# Altium schematic sheet MCU1.SchDoc
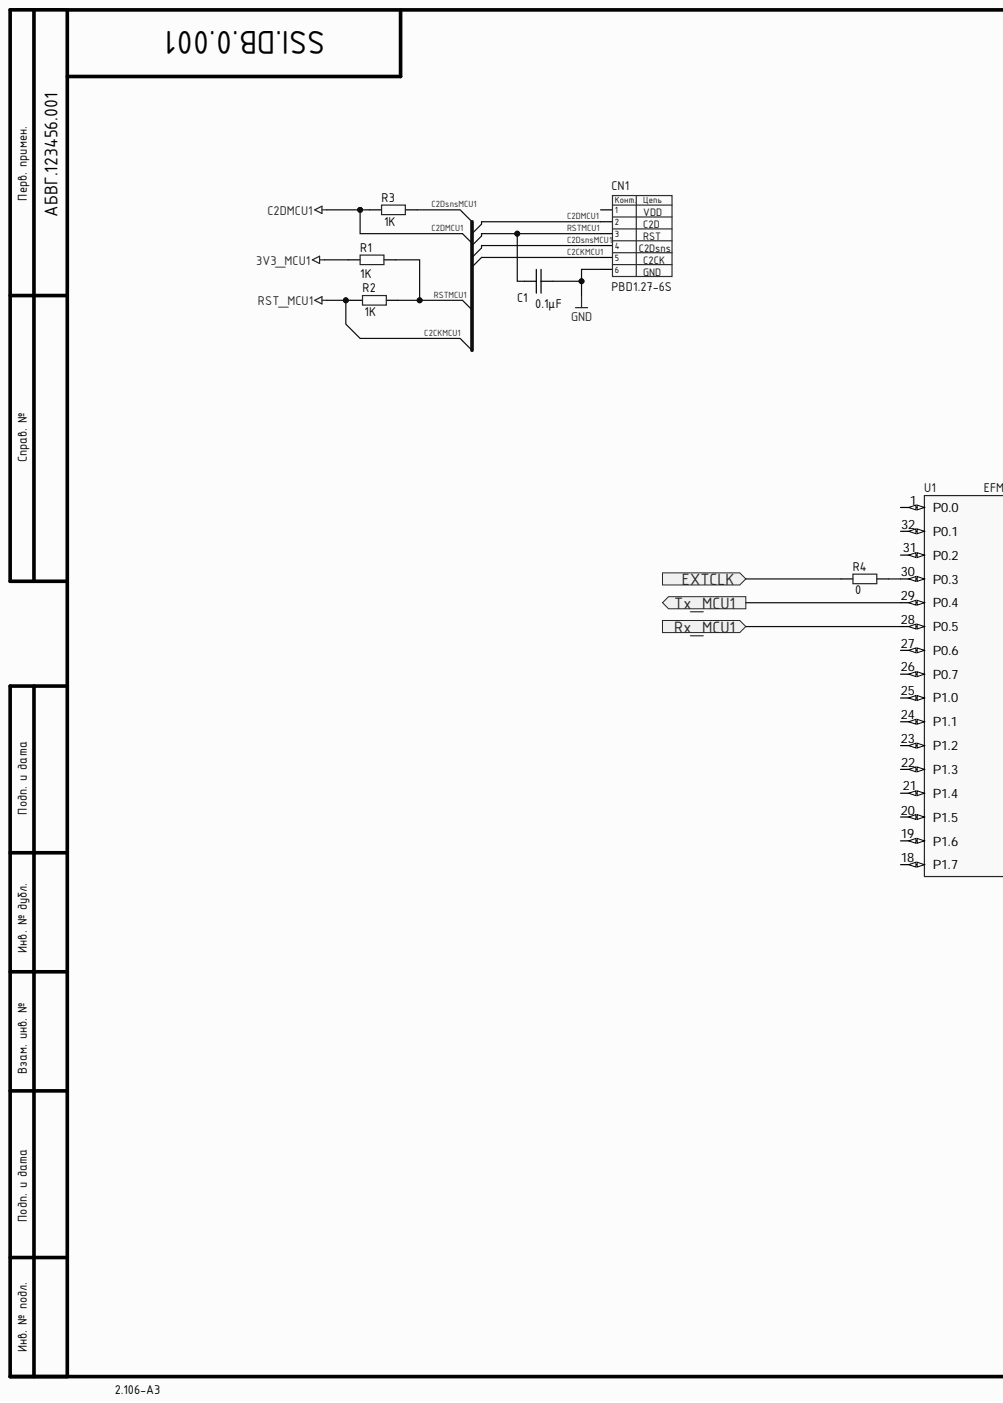
[diagram p0: MCU1.SchDoc, left]
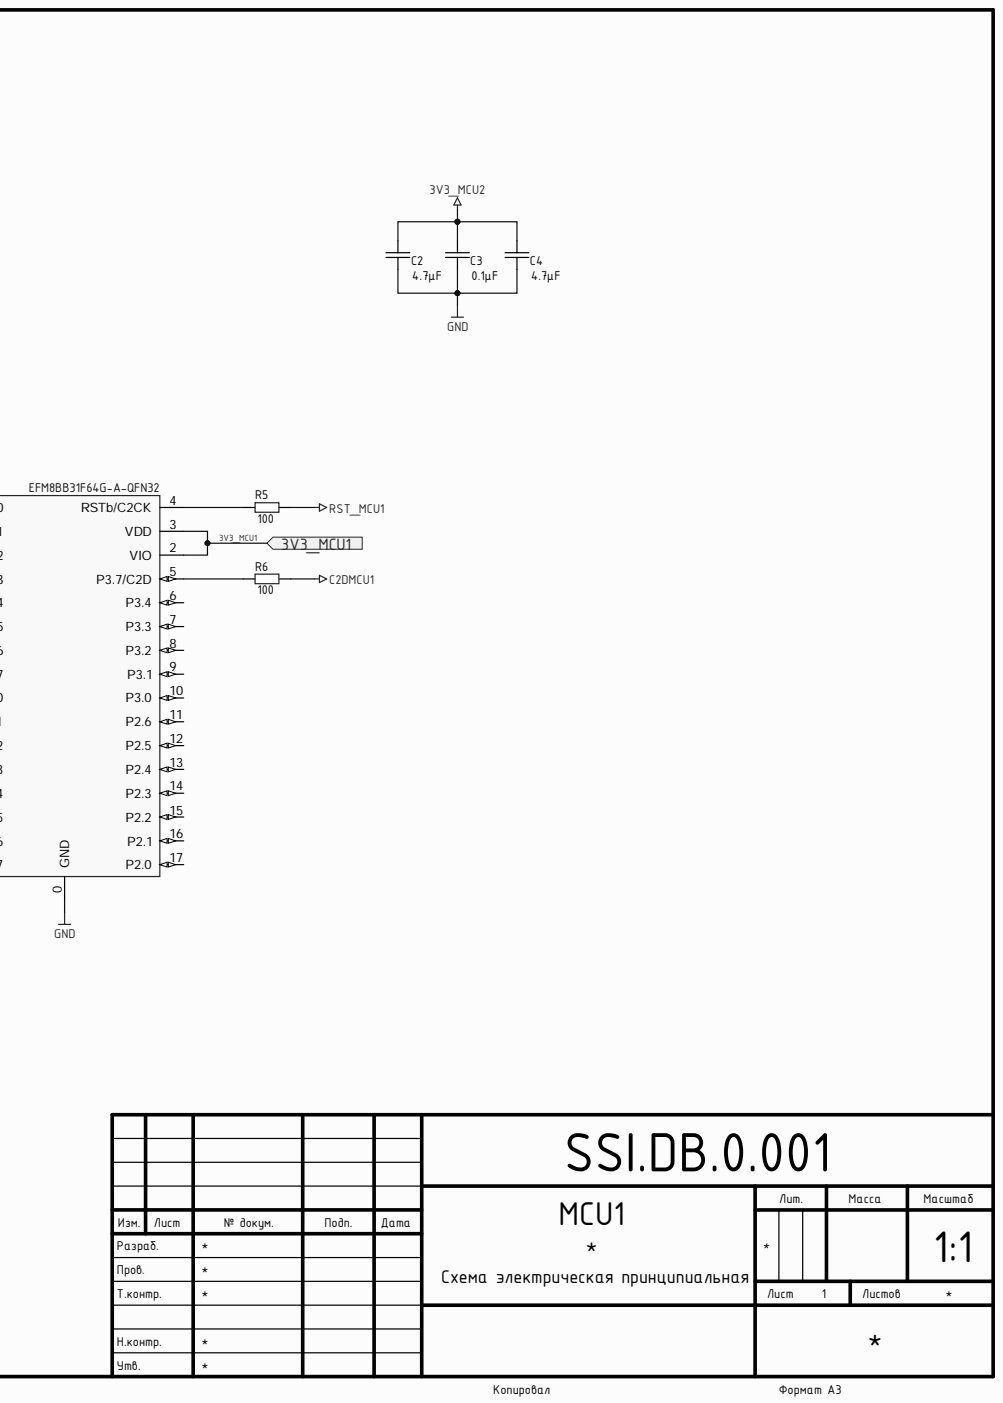
[diagram p1: MCU1.SchDoc, right]

## Parts
C1  value 0.1μF  [CAP: 0603]  (hidden comment: Ceramic capacitor, 0.1μF, X7R, ±10%, 50V, 0603, -55...+125°C)
C2  value 4.7μF  [CAP: 0603]  (hidden comment: Ceramic capacitor, 4.7μF, X7R, ±10%, 10V, 0603, -55...+125°C)
C3  value 0.1μF  [CAP: 0603]  (hidden comment: Ceramic capacitor, 0.1μF, X7R, ±10%, 50V, 0603, -55...+125°C)
C4  value 4.7μF  [CAP: 0603]  (hidden comment: Ceramic capacitor, 4.7μF, X7R, ±10%, 10V, 0603, -55...+125°C)
CN1  PBD1.27-6S  [CON:PBD1.27-6]
R1  value 1K  [RES: 0603]  (hidden comment: Resistor film, 1K, ±1%, 0603, 0.1W, -55...+125°C)
R2  value 1K  [RES: 0603]  (hidden comment: Resistor film, 1K, ±1%, 0603, 0.1W, -55...+125°C)
R3  value 1K  [RES: 0603]  (hidden comment: Resistor film, 1K, ±1%, 0603, 0.1W, -55...+125°C)
R4  value 0  [RES: 0603]  (hidden comment: Resistor film, 0, ±1%, 0603, 0.1W, -55...+125°C)
R5  value 100  [RES: 0603]  (hidden comment: Resistor film, 100, ±1%, 0603, 0.1W, -55...+125°C)
R6  value 100  [RES: 0603]  (hidden comment: Resistor film, 100, ±1%, 0603, 0.1W, -55...+125°C)
U1  EFM8BB31F64G-A-QFN32  [32-QFN(4x4)]

## Ports
3V3_MCU1, EXTCLK, Rx_MCU1, Tx_MCU1

## Nets
3V3_MCU2: C2.2 C3.2 C4.2
GND: C1.1 C2.1 C3.1 C4.1 CN1.6 U1.0(GND)
3V3_MCU1: R1.1 U1.2(VIO) U1.3(VDD) ; port 3V3_MCU1
C2CKMCU1: CN1.5 R2.2 R5.1
C2DMCU1: CN1.2 R3.2 R6.1
C2DsnsMCU1: CN1.4 R3.1
EXTCLK: R4.2 ; port EXTCLK
RSTMCU1: C1.2 CN1.3 R1.2 R2.1
Rx_MCU1: U1.28(P0.5) ; port Rx_MCU1
Tx_MCU1: U1.29(P0.4) ; port Tx_MCU1
NetR4_1: R4.1 U1.30(P0.3)
NetR5_2: R5.2 U1.4(RSTb/C2CK)
NetR6_2: R6.2 U1.5(P3.7/C2D)

Not connected: CN1 1; U1 1(P0.0) 6(P3.4) 7(P3.3) 8(P3.2) 9(P3.1) 10(P3.0) 11(P2.6) 12(P2.5) 13(P2.4) 14(P2.3) 15(P2.2) 16(P2.1) 17(P2.0) 18(P1.7) 19(P1.6) 20(P1.5) 21(P1.4) 22(P1.3) 23(P1.2) 24(P1.1) 25(P1.0) 26(P0.7) 27(P0.6) 31(P0.2) 32(P0.1)

## Library data of the parts
C1-C4 = Ceramic_0603: Manufacturer: Yageo; PartNumber: CC0603KRX7R7BB104; Component Kind: Standard; HelpURL: https://www.digikey.com/product-detail/en/yageo/CC0603KRX7R7BB104/311-1088-1-ND/302998; Library Name: capacitor.IntLib; Package: 0603; Temperature: -55...+125°C; Tolerance: ±10%; TypeCapacitor: Ceramic capacitor; TypeDielectric: X7R
  C1 C3: ValueManufacturerPartNumber: Ceramic capacitor, 0.1μF, X7R, ±10%, 50V, 0603, -55...+125°C; Voltage: 50V
  C2 C4: ValueManufacturerPartNumber: Ceramic capacitor, 4.7μF, X7R, ±10%, 10V, 0603, -55...+125°C; Voltage: 10V
R1-R6 = Resistor_0603: Manufacturer: Yageo; PartNumber: RC0603JR-07100RL; Component Kind: Standard; HelpURL: https://www.digikey.com/product-detail/en/yageo/RC0603JR-07100RL/311-100GRCT-ND/729644; Library Name: resistor.IntLib; Package: 0603; Power: 0.1W; Temperature: -55...+125°C; Tolerance: ±1%; TypeResistor: Resistor film
  R1-R3: ValueManufacturerPartNumber: Resistor film, 1K, ±1%, 0603, 0.1W, -55...+125°C
  R4: ValueManufacturerPartNumber: Resistor film, 0, ±1%, 0603, 0.1W, -55...+125°C
  R5 R6: ValueManufacturerPartNumber: Resistor film, 100, ±1%, 0603, 0.1W, -55...+125°C
U1 = EFM8BB31F64G-A-QFN32: 8051 MCU Core; Component Kind: Standard; Library Name: ic-mcu.IntLib
CN1 = PBD1.27-6S: Component Kind: Standard; Library Name: connector.IntLib
